# Altium schematic sheet Fan Kontrolü Şematik .SchDoc("Fan Kontrolü Şematik ")
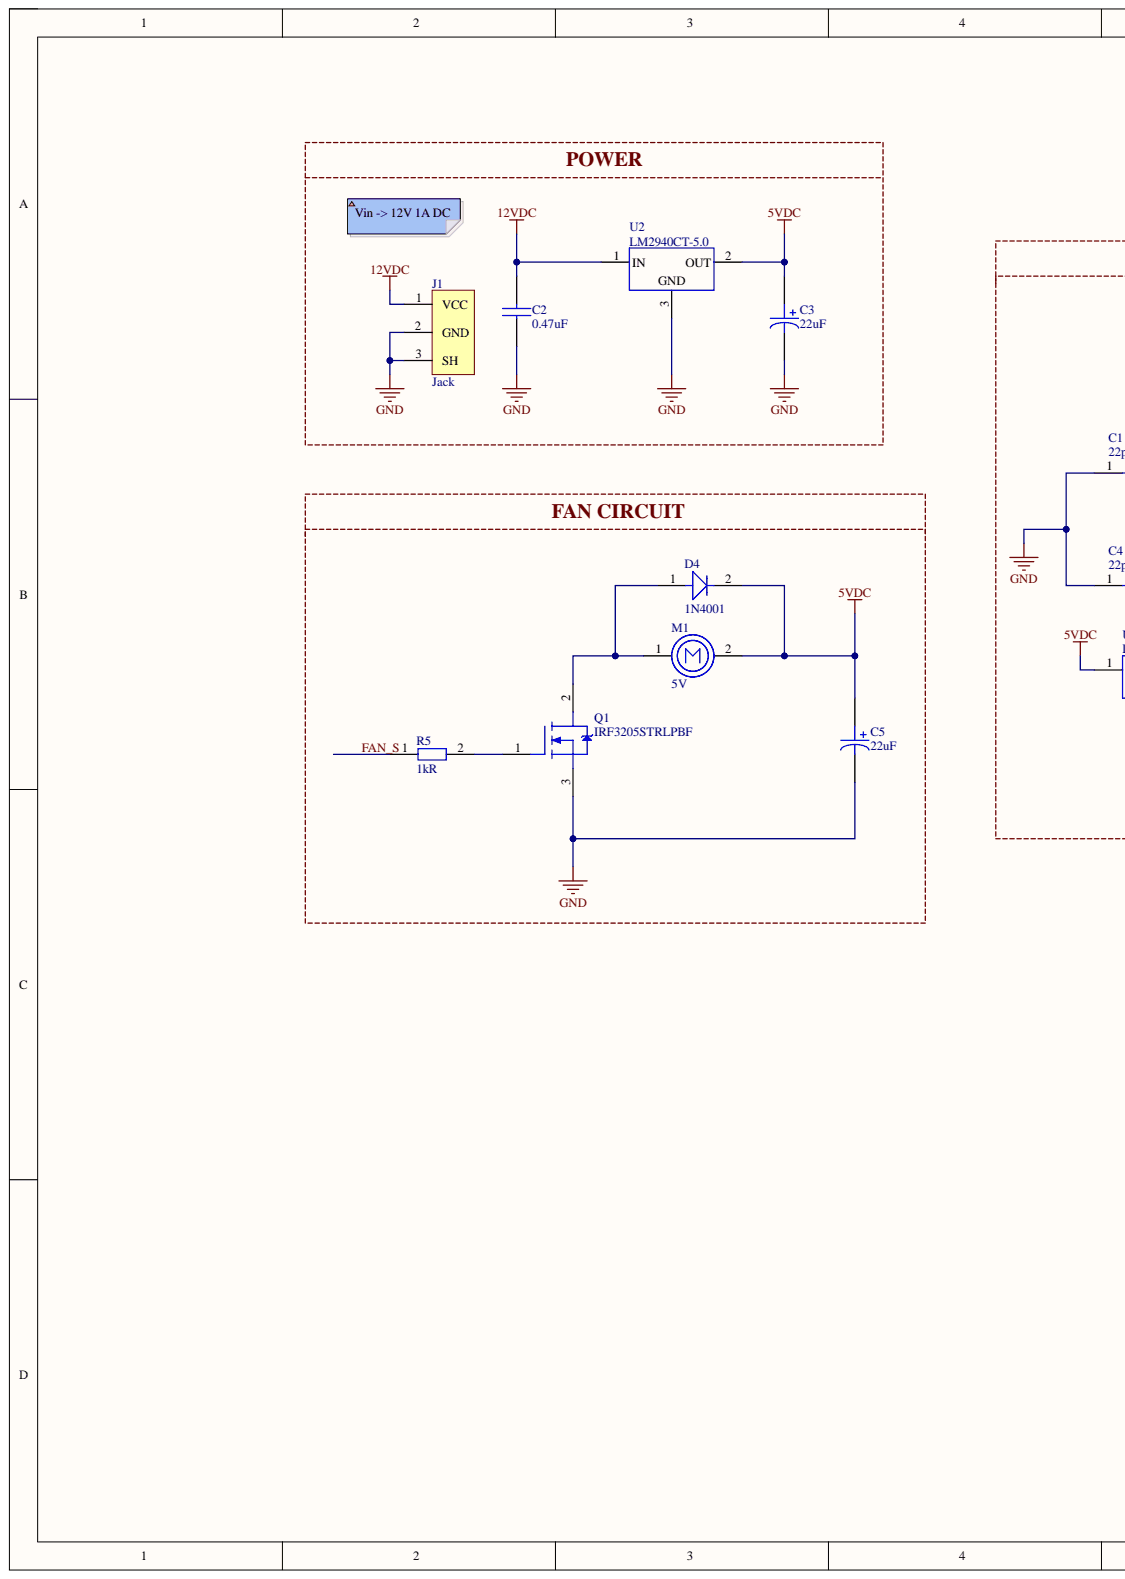
[diagram p0: Fan Kontrolü Şematik .SchDoc, left]
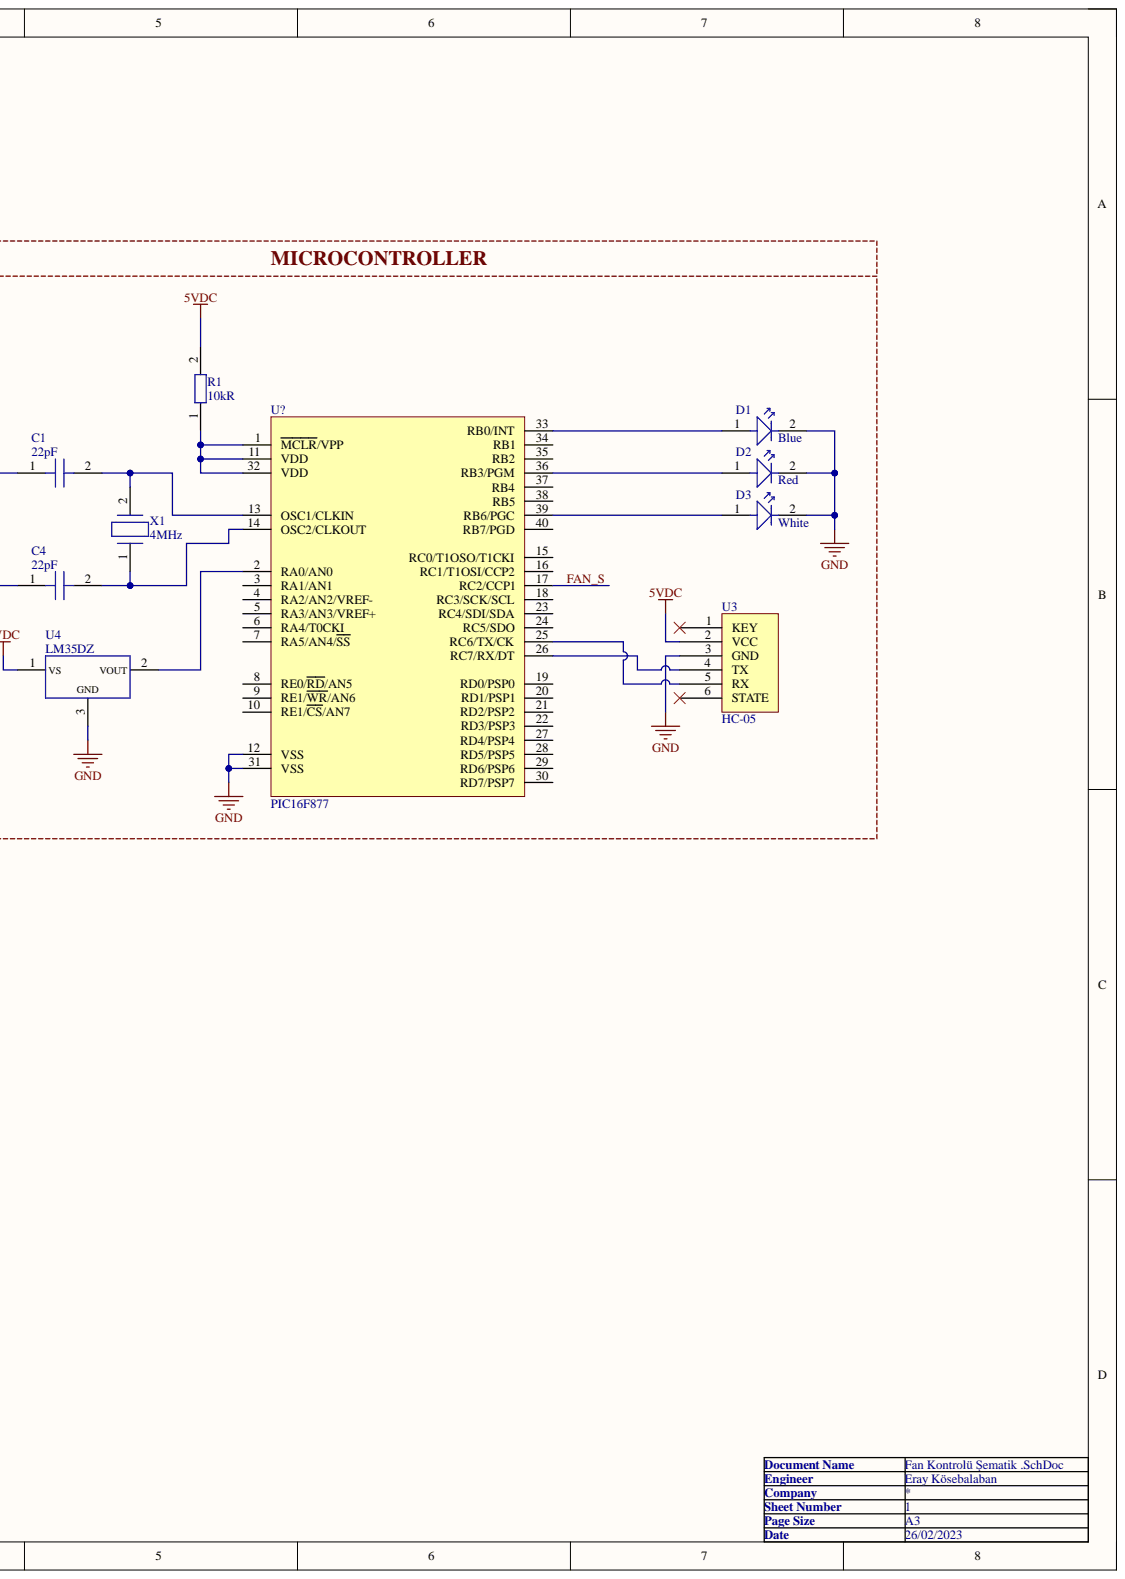
[diagram p1: Fan Kontrolü Şematik .SchDoc, right]

## Parts
C1  Capacitance: 22pF  [22pF CER. CAP.]  (hidden comment: K220J15C0GF5TL2)
C2  Capacitance: 0.47uF  [CAP_0805]  (hidden comment: CL21A475KAQNNNE)
C3  Capacitance: 22uF  [CAP_RADIAL_D10_P5]  (hidden comment: ESH477M050AH4AA)
C4  Capacitance: 22pF  [22pF CER. CAP.]  (hidden comment: K220J15C0GF5TL2)
C5  Capacitance: 22uF  [CAP_RADIAL_D10_P5]  (hidden comment: ESH477M050AH4AA)
D1  Color: Blue  [LED-BLUE]  (hidden comment: 151051BS04000)
D2  Color: Red  [LED-RED]  (hidden comment: L513SRD-B)
D3  Color: White  [LED-WHITE]  (hidden comment: L5C3NWC)
D4  1N4001  [DO-41]
J1  PJ-037AH-SMT-TR  [JACK]  (value not drawn)
M1  Operating Voltage: 5V  [2 Pin JST 1.25mm Connector]  (hidden comment: 4468)
Q1  IRF3205STRLPBF  [TO262-3]
R1  10k  Resistance: 10kR  [10k RES.]
R5  Resistance: 1kR  [1k RES.]  (hidden comment: CF14JT1K00)
U2  LM2940CT-5.0  [TO-220]
U3  HC-05  [HC-05]
U4  LM35DZ  [TO-92]
U?  PIC16F877  [DIP-40]
X1  Frequency: 4MHz  [HC-49]  (hidden comment: FC4STCBMF4.0)

## Nets
5VDC: C3.1 C5.1 D4.2 M1.2 R1.2 U2.2 U3.2(VCC) U4.1
12VDC: C2.2 J1.1 U2.1
GND: C1.1 C2.1 C3.2 C4.1 C5.2 D1.2 D2.2 D3.2 J1.2 J1.3 Q1.3 U2.3 U3.3(GND) U4.3 U?.12(VSS) U?.31(VSS)
FAN_S: R5.1 U?.17(RC2/CCP1)
NetC1_2: C1.2 U?.13(OSC1/CLKIN) X1.2
NetC4_2: C4.2 U?.14(OSC2/CLKOUT) X1.1
NetD1_1: D1.1 U?.33(RB0/INT)
NetD2_1: D2.1 U?.36(RB3/PGM)
NetD3_1: D3.1 U?.39(RB6/PGC)
NetD4_1: D4.1 M1.1 Q1.2
NetQ1_1: Q1.1 R5.2
NetR1_1: R1.1 U?.1(MCLR/VPP) U?.11(VDD) U?.32(VDD)
NetU3_4: U3.4(TX) U?.26(RC7/RX/DT)
NetU3_5: U3.5(RX) U?.25(RC6/TX/CK)
NetU4_2: U4.2 U?.2(RA0/AN0)

Not connected: U3 1(KEY) 6(STATE); U? 3(RA1/AN1) 4(RA2/AN2/VREF-) 5(RA3/AN3/VREF+) 6(RA4/T0CKI) 7(RA5/AN4/SS) 8(RE0/RD/AN5) 9(RE1/WR/AN6) 10(RE1/CS/AN7) 15(RC0/T1OSO/T1CKI) 16(RC1/T1OSI/CCP2) 18(RC3/SCK/SCL) 19(RD0/PSP0) 20(RD1/PSP1) 21(RD2/PSP2) 22(RD3/PSP3) 23(RC4/SDI/SDA) 24(RC5/SDO) 27(RD4/PSP4) 28(RD5/PSP5) 29(RD6/PSP6) 30(RD7/PSP7) 34(RB1) 35(RB2) 37(RB4) 38(RB5) 40(RB7/PGD)

## Library data of the parts
C1 C4 = 22pF CER. CAP.: Cap Ceramic 22pF 50V C0G 5% Radial 2.5mm 125°C T/R; Manufacturer: Vishay BCcomponents; Designed by: Eray Kösebalaban; Lead Diameter: 0.5mm; Lead Length: 30mm; Lead/Base Style: Straight; Case/Package: Dipped; Category: Ceramic Capacitors; Component Kind: Standard; ComponentLink1Description: https://datasheet.ciiva.com/145/kseries-145764.pdf?src-supplier=Digi-Key; ComponentLink1URL: https://datasheet.ciiva.com/145/kseries-145764.pdf?src-supplier=Digi-Key; ComponentLink2Description: https://datasheet.ciiva.com/145/kseries-145765.pdf?src-supplier=Digi-Key; ComponentLink2URL: https://datasheet.ciiva.com/145/kseries-145765.pdf?src-supplier=Digi-Key; ComponentLink3Description: https://datasheet.ciiva.com/18442/70121924-18442245.pdf?src-supplier=Allied+Electronics; ComponentLink3URL: https://datasheet.ciiva.com/18442/70121924-18442245.pdf?src-supplier=Allied+Electronics; Construction: RADIAL; Depth: 2.5mm; Dielectric: C0G; Dielectric Material: Ceramic; Height: 4mm; Height - Seated (Max): 0.22inch; Lead Free: Lead Free; Lead Pitch: 2.5mm; Length: 4mm; Library Name: Integrated_Library.IntLib; Manufacturer Part Number: K220J15C0GF5TL2; Max Operating Temperature: 125°C; Min Operating Temperature: -55°C; Mount: Through Hole,PCB,Radial; Number of Pins: 2; Package Quantity: 4000; Packaging: Tape and Reel; Pricing 1: 1=0,27000, 10=0,18100, 50=0,10860, 100=0,09170, 500=0,06276, 1000=0,05552 (USD); Radiation Hardening: No; RoHS: Yes; RoHS Compliant: Yes; Series: K; Stock 1: 24200; Supplier 1: Digi-Key; Supplier Part Number 1: BC1005CT-ND; Termination: Radial; Tolerance: 5%; Voltage: 50V; Voltage Rating: 50V; Voltage Rating (DC): 50V; Weight: 0.005291oz; Width: 4mm
C2 = CAP CER 4.7uF 0805: CAP CER 4.7UF 25V X5R 0805; Manufacturer: Samsung; Designed by: Eray Kösebalaban; Tolerance: 10%; Case Code (Metric): 2012; Case Code (Imperial): 0805; Case/Package: 0805; Category: Ceramic Capacitors; Component Kind: Standard; ComponentLink1Description: https://datasheet.ciiva.com/25798/cl21a475kaqnnne-25798882.pdf?src-supplier=Digi-Key; ComponentLink1URL: https://datasheet.ciiva.com/25798/cl21a475kaqnnne-25798882.pdf?src-supplier=Digi-Key; Construction: Flat; Depth: 1.25mm; Dielectric: X5R; Height: 1.25mm; Lead Free: Lead Free; Length: 2mm; Library Name: Wireless Security Detector Integrated_Library.IntLib; Manufacturer Part Number: CL21A475KAQNNNE; Max Operating Temperature: 85°C; Min Operating Temperature: -55°C; Mount: Surface Mount; Package Quantity: 1; Packaging: Tape and Reel; Pricing 1: 1=0,10000, 10=0,07300, 50=0,04140, 100=0,03440, 500=0,02408, 1000=0,01994 (USD); Radiation Hardening: No; RoHS: Yes; RoHS Compliant: Yes; Series: CL; Stock 1: 4392048; Supplier 1: Digi-Key; Supplier Part Number 1: 1276-1244-1-ND; Thickness: 0.055inch; Voltage Rating: 25V; Voltage Rating (DC): 25V; Width: 0.049inch
C3 C5 = CAP ALU ELEC 470uF 50V: Cap, 470UF, 50V, Alu Elec, Radial; Manufacturer: KEMET; Designed by: Eray Kösebalaban; Life (Hours): 2000Hour; Polarity: Polar; Tolerance: 20%; Case/Package: Radial; Component Kind: Standard; ComponentLink1Description: https://api.kemet.com/component-edge/download/datasheet/ESH477M050AH4AA.pdf?src-supplier=Digi-Key; ComponentLink1URL: https://api.kemet.com/component-edge/download/datasheet/ESH477M050AH4AA.pdf?src-supplier=Digi-Key; Library Name: Wireless Security Detector Integrated_Library.IntLib; Manufacturer Part Number: ESH477M050AH4AA; Max Operating Temperature: 105°C; Min Operating Temperature: -40°C; Mount: Through Hole; Packaging: Bulk; Pricing 1: 1=0,73000, 10=0,51800, 50=0,39180, 100=0,34120, 500=0,25276, 1000=0,21485, 2500=0,20222, 5000=0,18958 (USD); RoHS: Yes; RoHS Compliant: No; Supplier 1: Digi-Key; Supplier Part Number 1: 399-15683-ND; Voltage Rating: 50V
D1 = LED-BLUE: LED BLUE DIFFUSED 5MM ROUND T/H  Blue 470nm LED Indication - Discrete 3.2V Radial; Current: 20mA; Designed by: Eray Kösebalaban; Millicandela Rating: 500mcd; Component Kind: Standard; Library Name: Integrated_Library.IntLib; Mounting Type: Through Hole; Size/Dimension: 5mm Dia; Voltage - Forward (Vf: 3.2V
D2 = LED-RED: 5MM RED LED LAMP   Red LED Indication - Discrete 1.8V Radial; Current: 20mA; Designed by: Eray Kösebalaban; Millicandela Rating: 80mcd; Component Kind: Standard; Library Name: Integrated_Library.IntLib; Mounting Type: Through Hole; Size / Dimension: 5mm Dia; Voltage-Forward (Vf): 1.8V
D3 = LED-WHITE: 5MM FLAT TOP WHITE LED LAMP  White LED Indication - Discrete 3.5V Radial; Current: 20mA; Designed by: Eray Kösebalaban; Millicandela Rating: 450 mcd; Component Kind: Standard; Library Name: Integrated_Library.IntLib; Mounting Type: Through Hole; Size / Dimension: 5mm Dia; Voltage-Forward (Vf): 3.5V
D4 = 1N4001: Rectifier Diode, 1 Element, 1A, 50V V(RRM), Silicon, DO-41; Manufacturer: ON Semiconductor / Fairchild; Capacitance: 15pF; Designed by: Eray Kösebalaban; Element Configuration: Single; Forward Voltage: 1.1V; Max Forward Surge Current (Ifsm): 30A; Max Reverse Current: 5uA; Polarity: Standard; Power Dissipation: 3W; Average Rectified Current: 1A; Case/Package: DO-41; Category: Rectifier Diodes; Component Kind: Standard; ComponentLink1Description: https://datasheet.ciiva.com/910/1n4001-910352.pdf?src-supplier=Avnet; ComponentLink1URL: https://datasheet.ciiva.com/910/1n4001-910352.pdf?src-supplier=Avnet; ComponentLink2Description: https://datasheet.ciiva.com/910/1n4001-910352.pdf?src-supplier=Digi-Key; ComponentLink2URL: https://datasheet.ciiva.com/910/1n4001-910352.pdf?src-supplier=Digi-Key; ComponentLink3Description: https://datasheet.ciiva.com/4452/1n4004-81693-4452416.pdf?src-supplier=Mouser; ComponentLink3URL: https://datasheet.ciiva.com/4452/1n4004-81693-4452416.pdf?src-supplier=Mouser; ComponentLink4Description: https://datasheet.ciiva.com/30479/0900766b810c07cd-30479188.pdf?src-supplier=Rs; ComponentLink4URL: https://datasheet.ciiva.com/30479/0900766b810c07cd-30479188.pdf?src-supplier=Rs; ComponentLink5Description: https://datasheet.ciiva.com/8084/1n4007-8084076.pdf?src-supplier=Avnet; ComponentLink5URL: https://datasheet.ciiva.com/8084/1n4007-8084076.pdf?src-supplier=Avnet; ComponentLink6Description: https://datasheet.ciiva.com/910/1n4001-910353.pdf?src-supplier=Digi-Key; ComponentLink6URL: https://datasheet.ciiva.com/910/1n4001-910353.pdf?src-supplier=Digi-Key; ComponentLink7Description: https://datasheet.ciiva.com/927/1n4001-d-927540.pdf?src-supplier=Digi-Key; ComponentLink7URL: https://datasheet.ciiva.com/927/1n4001-d-927540.pdf?src-supplier=Digi-Key; ComponentLink8Description: https://datasheet.ciiva.com/22651/1923868-22651932.pdf?src-supplier=Element14; ComponentLink8URL: https://datasheet.ciiva.com/22651/1923868-22651932.pdf?src-supplier=Element14; ComponentLink9Description: https://datasheet.ciiva.com/22685/1504060-22685765.pdf?src-supplier=Element14; ComponentLink9URL: https://datasheet.ciiva.com/22685/1504060-22685765.pdf?src-supplier=Element14; ComponentLink10Description: https://datasheet.ciiva.com/15208/getdatasheetpartid-148723-15208346.pdf?src-supplier=Verical; ComponentLink10URL: https://datasheet.ciiva.com/15208/getdatasheetpartid-148723-15208346.pdf?src-supplier=Verical; Contact Plating: Tin,Matte; Current Rating: 1A; Diameter: 2.72mm; Forward Current: 1A; Height: 5.2mm; Lead Free: Lead Free; Length: 5.2mm; Library Name: Integrated_Library.IntLib; Manufacturer Part Number: 1N4001; Max Operating Temperature: 175°C; Max Repetitive Reverse Voltage (Vrrm): 50V; Max Reverse Voltage (DC): 50V; Max Surge Current: 30A; Min Operating Temperature: -55°C; Mount: Through Hole; Number of Pins: 2; Output Current: 1A; Package Quantity: 1000; Packaging: Tape and Reel; Peak Non-Repetitive Surge Current: 30A; Pricing 1: 1=0,17000 (USD); Radiation Hardening: No; Reach SVHC Compliant: Yes; Reverse Voltage: 50V; RoHS: Yes; RoHS Compliant: Yes; Series: -; Supplier 1: Digi-Key; Supplier Part Number 1: 1N4001FSCT-ND; Voltage Rating (DC): 50V; Weight: 0.245g; Width: 0.25inch
J1 = PJ-037AH-SMT-TR: 2.0 x 6.3 mm, 5.0 A, Horizontal, Surface Mount (SMT), Dc Power Jack Connector; Manufacturer: CUI; Current Rating: 5A; Designed by: Eray Kösebalaban; Gender: Male; Number of Conductors: 2; Shielding: Unshielded; Voltage Rating: 24V; Insulation Resistance: 100MR; Case/Package: SMD/SMT; Category: Uncategorized; Color: Black; Component Kind: Standard; ComponentLink1Description: http://www.mouser.com/ds/2/670/pj-037ah-smt-516065.pdf?src-supplier=Mouser; ComponentLink1URL: http://www.mouser.com/ds/2/670/pj-037ah-smt-516065.pdf?src-supplier=Mouser; ComponentLink2Description: https://www.cui.com/product/resource/digikeypdf/pj-037ah-smt-tr.pdf?src-supplier=Digi-Key; ComponentLink2URL: https://www.cui.com/product/resource/digikeypdf/pj-037ah-smt-tr.pdf?src-supplier=Digi-Key; ComponentLink3Description: http://www.cui.com/product/resource/digikeypdf/pj-037ah-smt.pdf?src-supplier=Digi-Key; ComponentLink3URL: http://www.cui.com/product/resource/digikeypdf/pj-037ah-smt.pdf?src-supplier=Digi-Key; Connector Type: Jack; Contact Gender: Male; Contact Material: Copper; Contact Plating: Nickel; Flammability Rating: UL94 V-0; Housing Color: Black; Housing Material: Thermoplastic; Library Name: Wireless Security Detector Integrated_Library.IntLib; Manufacturer Part Number: PJ-037AH-SMT-TR; Max Operating Temperature: 85°C; Min Operating Temperature: -25°C; Mount: Surface Mount,Through Hole; Orientation: Horizontal; Package Quantity: 200; Packaging: Tape and Reel; RoHS: Yes; Supplier 1: Digi-Key; Supplier Part Number 1: CP-037AHPJCT-ND; Termination: Solder
M1 = FAN-DC: Miniature 5V Cooling Fan with Molex PicoBlade Connector; Manufacturer: Adafruit Industries; Current: 0.2A; Designed by: Eray Kösebalaban; Component Kind: Standard; Dimensions: 30x30x8mm; Library Name: Integrated_Library.IntLib; Manufacturer Part Number: 4468; RoHS: No; Supplier 1: Digi-Key; Supplier Part Number 1: 1528-4468-ND
Q1 = IRF3205S: MOSFET N-CH 55V 110A D2PAK; Manufacturer: Infineon; Designed by: Eray Kösebalaban; Drain to Source Resistance: 8mR; Gate to Source Voltage (Vgs): 20V; Max Power Dissipation: 200W; Polarization: N; Threshold Voltage: 4V; Turn-Off Delay Time: 50ns; Turn-On Delay Time: 14ns; Input Capacitance: 3.247nF; Case/Package: D2PAK; Category: MOSFETs; Component Kind: Standard; Continuous Drain Current (ID): 110A; Drain to Source Breakdown Voltage: 55V; Drain to Source Voltage (Vdss): 55V; Halogen Free: Halogen Free; Height: 0.19inch; Lead Free: Contains Lead; Length: 0.42inch; Library Name: Integrated_Library.IntLib; Manufacturer Part Number: IRF3205STRLPBF; Max Dual Supply Voltage: 55V; Max Operating Temperature: 175°C; Min Operating Temperature: -55°C; Mount: Surface Mount; Number of Pins: 3; Packaging: Cut Tape; Power Dissipation: 200W; Pricing 1: 1=1,88000, 10=1,69300, 100=1,36080 (USD); Rds On Max: 8mR; Reach SVHC Compliant: Yes; RoHS: Yes; RoHS Compliant: Yes; Series: HEXFET®; Stock 1: 2759; Supplier 1: Digi-Key; Supplier Part Number 1: IRF3205STRLPBFCT-ND; Width: 0.38inch
R1 = 10k RES.: 10 kOhms ±5% 0.25W, 1/4W Through Hole Resistor Axial Carbon Film; Manufacturer: Yageo; Manufacturer Part Number: CFR25SJT-52-10K; Designed by: Eray Kösebalaban; Temperature Coefficient: -500/ +350ppm/°C; Category: Through-Hole Resistors; Component Kind: Standard; Composition: 0.25W, 1/4W; Library Name: Integrated_Library.IntLib; Operating Temperature: -55°C ~ -155°C; Power (Watts): 0.25W, 1/4W; Pricing 1: 1=0,10000, 10=0,06400, 25=0,04640, 50=0,03540, 100=0,02600, 250=0,01988, 500=0,01588, 1000=0,01170 (USD); RoHS: No; Size / Dimension: 0.075" Dia x 0.134" L (1.90mm x 3.40mm); Stock 1: 25082; Supplier 1: Digi-Key; Supplier Device Package: Axial; Supplier Part Number 1: 13-CFR25SJT-52-10KCT-ND; Tolerance: ±5%
R5 = 1k RES.: Res Carbon Film 1K Ohm 5% 1/4W -400ppm/°C to 0ppm/°C Conformal AXL Thru-Hole T/R; Manufacturer: Stackpole Electronics; Designed by: Eray Kösebalaban; Max Power Dissipation: 250mW; Case/Package: Conformal; Category: Through-Hole Resistors; Component Kind: Standard; ComponentLink1Description: http://components.arrow.com/part/dataview/27620077S9848643N1174?src-supplier=Arrow+Electronics; ComponentLink1URL: http://components.arrow.com/part/dataview/27620077S9848643N1174?src-supplier=Arrow+Electronics; ComponentLink2Description: http://www.seielect.com/Catalog/SEI-CF_CFM.pdf?src-supplier=Future+Electronics; ComponentLink2URL: http://www.seielect.com/Catalog/SEI-CF_CFM.pdf?src-supplier=Future+Electronics; ComponentLink3Description: https://www.seielect.com/catalog/SEI-CF_CFM.pdf?src-supplier=Avnet; ComponentLink3URL: https://www.seielect.com/catalog/SEI-CF_CFM.pdf?src-supplier=Avnet; ComponentLink4Description: https://www.seielect.com/catalog/SEI-Packaging.pdf?src-supplier=Digi-Key; ComponentLink4URL: https://www.seielect.com/catalog/SEI-Packaging.pdf?src-supplier=Digi-Key; ComponentLink5Description: https://www.seielect.com/Catalog/SEI-CF_CFM.pdf?src-supplier=Digi-Key; ComponentLink5URL: https://www.seielect.com/Catalog/SEI-CF_CFM.pdf?src-supplier=Digi-Key; ComponentLink6Description: https://www.verical.com/server-webapp/html/getDatasheet?partID=360403&src-supplier=Verical; ComponentLink6URL: https://www.verical.com/server-webapp/html/getDatasheet?partID=360403&src-supplier=Verical; Construction: Cylindrical; Contact Plating: Tin,Matte; Diameter: 2.3mm; Features: Flame Retardant Coating; Lead Free: Lead Free; Length: 6.5mm; Library Name: Integrated_Library.IntLib; Manufacturer Part Number: CF14JT1K00; Max Operating Temperature: 155°C; Military Standard: Not; Min Operating Temperature: -55°C; Mount: Through Hole,Axial; Number of Terminations: 2; Package Quantity: 1; Packaging: Tape and Reel; Power Rating: 250mW; Pricing 1: 1=0,10000, 10=0,05600, 25=0,04040, 50=0,03080, 100=0,02260, 250=0,01728, 500=0,01382, 1000=0,01017 (USD); Radiation Hardening: No; Resistor Type: Carbon Film; RoHS: Yes; RoHS Compliant: Yes; Series: CF; Stock 1: 2797151; Supplier 1: Digi-Key; Supplier Part Number 1: CF14JT1K00CT-ND; Temperature Coefficient: -400ppm/°C; Termination: Axial; Tolerance: 5%
U2 = LM2940CT-5.0/LF01: IC REG LINEAR 5V 1A TO220-3; Manufacturer: Texas Instruments; Designed by: Eray Kösebalaban; Dropout Voltage: 500mV; Max Input Voltage: 26V; Min Input Voltage: 6.25V; Number of Outputs: 1; Output Type: Fixed; Polarity: Positive; Quiescent Current: 15mA; Case/Package: TO-220; Category: Voltage Regulators - Linear; Component Kind: Standard; ComponentLink1Description: https://datasheet.ciiva.com/14275/lm2940c-14275534.pdf?src-supplier=Avnet; ComponentLink1URL: https://datasheet.ciiva.com/14275/lm2940c-14275534.pdf?src-supplier=Avnet; Lead Free: Contains Lead; Library Name: Integrated_Library.IntLib; Manufacturer Part Number: LM2940CT-5.0/LF01; Max Operating Temperature: 85°C; Min Operating Temperature: -40°C; Mount: Through Hole; Output Current: 1A; Output Voltage: 5V; Package Quantity: 45; Packaging: Rail/Tube; Pricing 1: 1=1,96000, 10=1,76400, 25=1,66400, 100=1,41790, 250=1,33136, 500=1,16494, 1000=0,96524, 2500=0,94200 (USD); Radiation Hardening: No; RoHS: Yes; RoHS Compliant: Yes; Stock 1: 1380; Supplier 1: Digi-Key; Supplier Part Number 1: 296-LM2940CT-5.0/LF01-ND
U3 = HC-05: HC-05 Bluetooth Module; Designed by: Eray Kösebalaban; Component Kind: Standard; Library Name: Integrated_Library.IntLib
U4 = LM35DZ: Temperature Sensor Analog, Local 0°C ~ 100°C 10mV/°C TO-92-3; Manufacturer: TI National Semiconductor; Manufacturer Part Number: LM35DZ/NOPB; Designed by: Eray Kösebalaban; Max Supply Voltage: 30V; Min Supply Voltage: 4V; Operating Supply Current: 91.5uA; Output Current: 10mA; Output Type: Voltage,Analog Voltage,Analog; Quiescent Current: 56uA; Case/Package: TO-92; Category: Integrated Circuits (ICs); Component Kind: Standard; ComponentLink1Description: https://datasheet.ciiva.com/19482/1276463-19482932.pdf?src-supplier=Jameco; ComponentLink1URL: https://datasheet.ciiva.com/19482/1276463-19482932.pdf?src-supplier=Jameco; ComponentLink2Description: https://datasheet.ciiva.com/1514/1896190-1514203.pdf?src-supplier=Element14; ComponentLink2URL: https://datasheet.ciiva.com/1514/1896190-1514203.pdf?src-supplier=Element14; ComponentLink3Description: https://datasheet.ciiva.com/6476/lm35-6476186.pdf?src-supplier=Digi-Key; ComponentLink3URL: https://datasheet.ciiva.com/6476/lm35-6476186.pdf?src-supplier=Digi-Key; Contact Plating: Tin; Height: 5.2mm; Lead Free: Lead Free; Length: 5.2mm; Library Name: Integrated_Library.IntLib; Max Operating Temperature: 110°C; Max Supply Voltage (DC): 30V; Min Operating Temperature: -40°C; Min Supply Voltage (DC): 4V; Mount: Through Hole; Number of Pins: 3; Output: ANALOG; Package Quantity: 1800; Packaging: Bulk; Pricing 1: 1=2,49000, 5=2,36000, 10=2,02200, 25=1,61760, 50=1,48280, 100=1,34800, 500=1,17950, 1000=1,04470, 5000=1,01100 (USD); Radiation Hardening: No; Reach SVHC Compliant: Yes; RoHS: Yes; RoHS Compliant: Yes; Series: -; Supplier 1: Digi-Key; Supplier Part Number 1: LM35DZ/NOPB-ND; Weight: 0.01lb; Width: 4.19mm; Interface: Analog
U? = PIC16F877: PIC16F Series 14 kB Flash 368 B RAM 20 MHz 8-Bit Microcontroller - PDIP-40; Manufacturer: Microchip; Manufacturer Part Number: PIC16F877-20/P; Access Time: 20us; Address Bus Width: 8b; Core Architecture: PIC; Designed by: Eray Kösebalaban; Frequency: 20MHz; Max Power Dissipation: 1W; Max Supply Voltage: 5.5V; Memory Size: 14KB; Min Supply Voltage: 4V; Nominal Supply Current: 1.6mA; Number of A/D Converters: 1; Number of ADC Channels: 8; Number of Programmable I/O: 33; Number of PWM Channels: 2; Number of Timers/Counters: 3; Number of USB Channels: 0; Oscillator Type: External; Peripherals: Brown-out Detect/Reset,POR,PWM,WDT,I2C; RAM Size: 368B; Watchdog Timer: Yes; Interface: USART,I2C,SPI,UART,SSP; Case/Package: PDIP; Category: Microcontrollers; Component Kind: Standard; ComponentLink1Description: https://datasheet.ciiva.com/19481/176882-19481594.pdf?src-supplier=Jameco; ComponentLink1URL: https://datasheet.ciiva.com/19481/176882-19481594.pdf?src-supplier=Jameco; ComponentLink2Description: https://datasheet.ciiva.com/7774/30292c-7774267.pdf?src-supplier=Element14; ComponentLink2URL: https://datasheet.ciiva.com/7774/30292c-7774267.pdf?src-supplier=Element14; ComponentLink3Description: https://datasheet.ciiva.com/14131/capic16f87x-e-14131992.pdf?src-supplier=Distrelec; ComponentLink3URL: https://datasheet.ciiva.com/14131/capic16f87x-e-14131992.pdf?src-supplier=Distrelec; ComponentLink4Description: https://datasheet.ciiva.com/4851/30292c-55665-4851245.pdf?src-supplier=Mouser; ComponentLink4URL: https://datasheet.ciiva.com/4851/30292c-55665-4851245.pdf?src-supplier=Mouser; ComponentLink5Description: https://datasheet.ciiva.com/7774/30292c-7774268.pdf?src-supplier=Element14; ComponentLink5URL: https://datasheet.ciiva.com/7774/30292c-7774268.pdf?src-supplier=Element14; ComponentLink6Description: https://datasheet.ciiva.com/3203/30292c-3203493.pdf?src-supplier=Avnet; ComponentLink6URL: https://datasheet.ciiva.com/3203/30292c-3203493.pdf?src-supplier=Avnet; ComponentLink7Description: https://datasheet.ciiva.com/16478/filehandler-aspxddocname-en011185-16478667.pdf?src-supplier=Future+Electronics; ComponentLink7URL: https://datasheet.ciiva.com/16478/filehandler-aspxddocname-en011185-16478667.pdf?src-supplier=Future+Electronics; ComponentLink8Description: https://datasheet.ciiva.com/29331/651653-da-01-en-ic-mcu-pic16f877-20-p-dip-40-mcp-29331196.pdf?src-supplier=Conrad; ComponentLink8URL: https://datasheet.ciiva.com/29331/651653-da-01-en-ic-mcu-pic16f877-20-p-dip-40-mcp-29331196.pdf?src-supplier=Conrad; Data Bus Width: 8b; Height: 4.06mm; Lead Free: Lead Free; Length: 52.45mm; Library Name: Integrated_Library.IntLib; Max Frequency: 20MHz; Max Operating Temperature: 70°C; Memory Type: FLASH; Min Operating Temperature: 0°C; Number of Bits: 8; Number of Pins: 40; Number of SPI Channels: 1; Number of UART Channels: 1; Number of I2C Channels: 1; Number of I/Os: 33; Package Quantity: 10; Packaging: Rail/Tube; Pricing 1: 1=9,08000 (USD); Radiation Hardening: No; Reach SVHC Compliant: Yes; RoHS: Yes; RoHS Compliant: Yes; Series: PIC® 16F; Stock 1: 153; Supplier 1: Digi-Key; Supplier Part Number 1: PIC16F877-20/P-ND; Supply Current: 1.6mA; Width: 14.22mm
X1 = CRYSTAL: Crystal 4MHz ±30ppm (Tol) ±50ppm (Stability) 20pF FUND 200Ohm 2-Pin HC-49/SLF Thru-Hole; Manufacturer: Fox Electronics; Designed by: Eray Kösebalaban; ESR (Equivalent Series Resistance): 150 Ohms; Load Capacitance: 22pF; Component Kind: Standard; Frequency Stability: ±50ppm; Frequency Tolerance: ±30ppm; Library Name: Integrated_Library.IntLib; Manufacturer Part Number: FC4STCBMF4.0; Operating Temperature: -20°C ~ 70°C; Pricing 1: 1=0,38000, 10=0,31600, 50=0,28400, 100=0,25250, 500=0,23988, 1000=0,20200, 2500=0,18938, 5000=0,18306, 10000=0,17675 (USD); Stock 1: 9276; Supplier 1: Digi-Key; Supplier Part Number 1: 631-FC4STCBMF4.0-ND
